# Altium schematic sheet MINIECO-ECO-CPU.SchDoc(MINIECO-ECO-CPU)
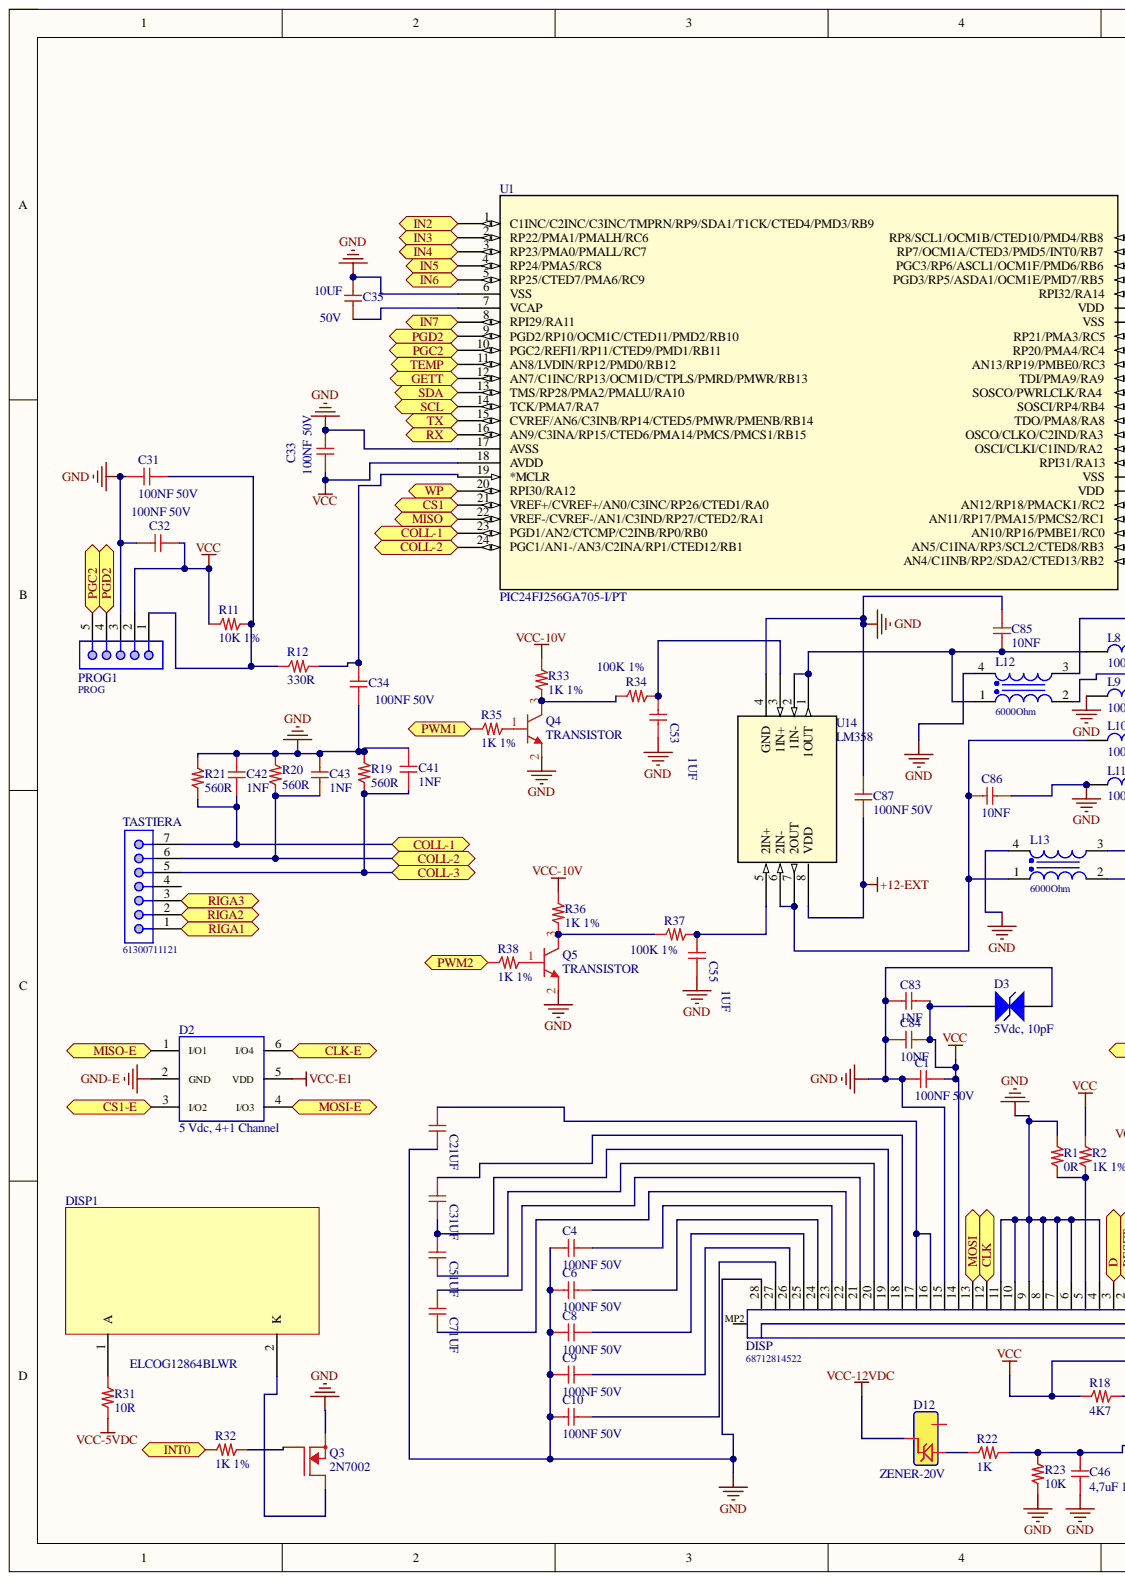
[diagram p0: MINIECO-ECO-CPU.SchDoc, left]
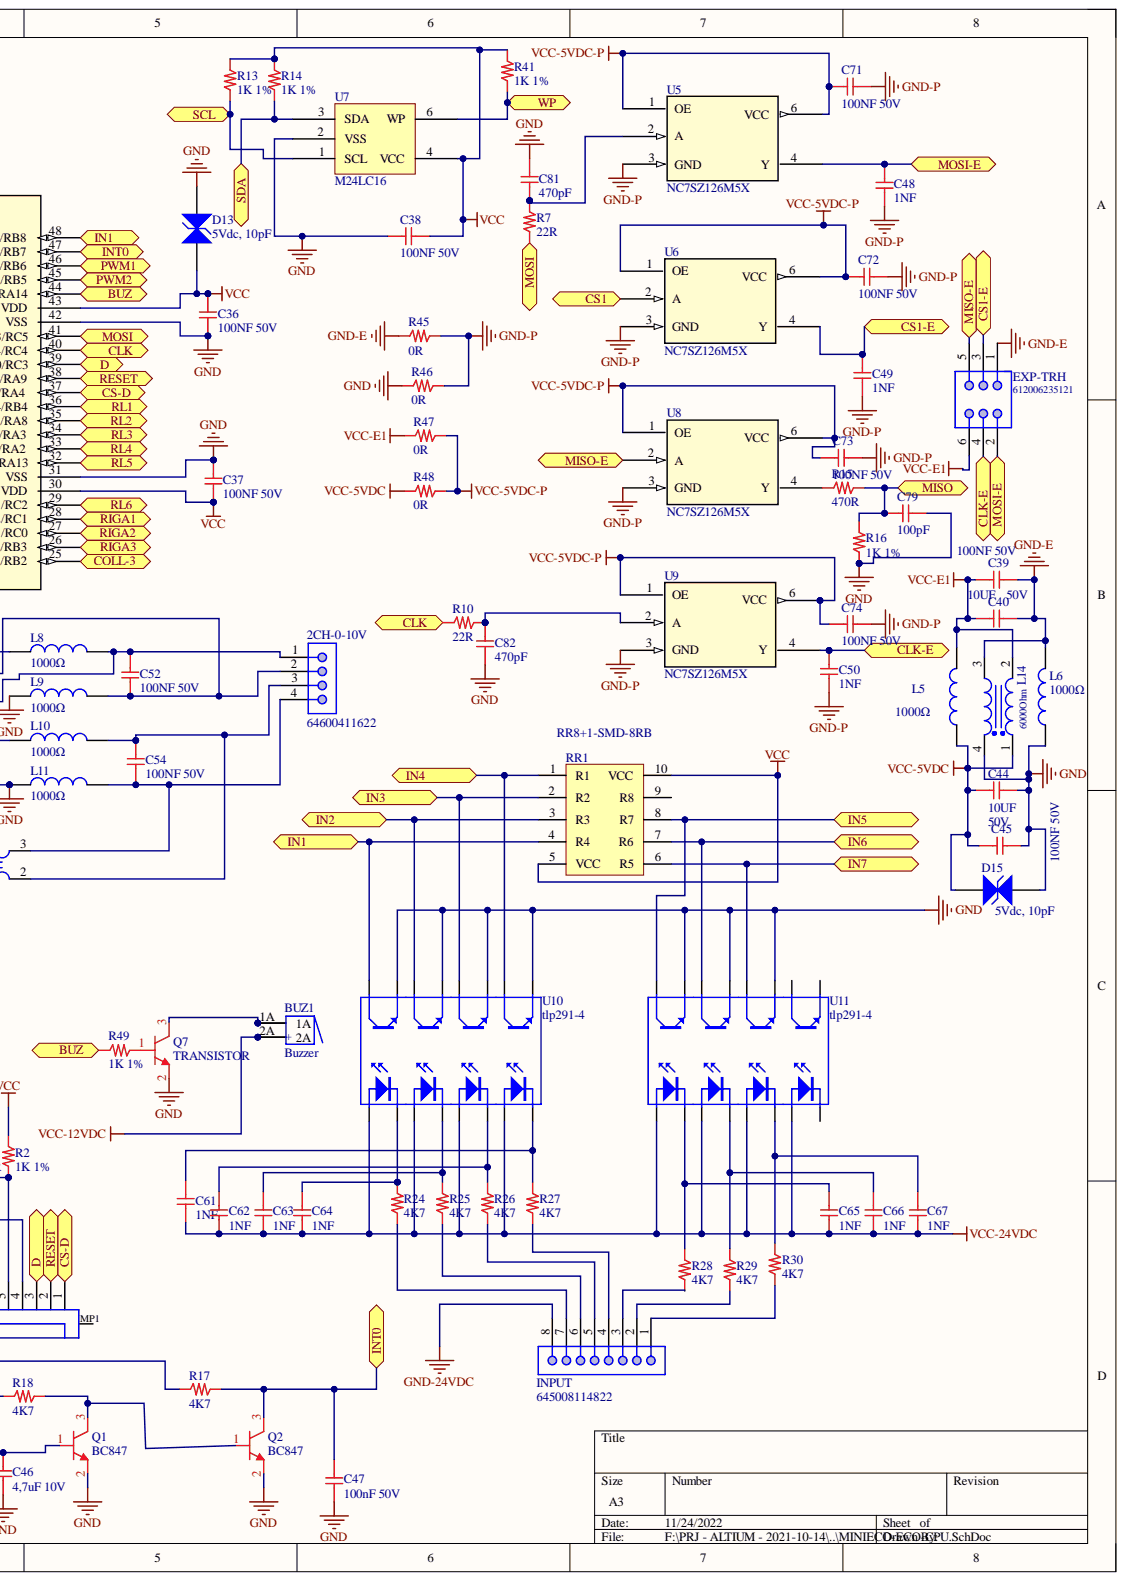
[diagram p1: MINIECO-ECO-CPU.SchDoc, right]

## Parts
2CH-0-10V  64600411622  [64600411622]
BUZ1  Buzzer  [BUZZER-14mm]
C1  100NF 50V  [0603_c]
C2  1UF  [0805_C]  (value not drawn)
C3  1UF  [0805_C]  (value not drawn)
C4  100NF 50V  [0603_c]
C5  1UF  [0805_C]  (value not drawn)
C6  100NF 50V  [0603_c]
C7  1UF  [0805_C]  (value not drawn)
C8  100NF 50V  [0603_c]
C9  100NF 50V  [0603_c]
C10  100NF 50V  [0603_c]
C31  100NF 50V  [0603_c]
C32  100NF 50V  [0603_c]
C33  100NF 50V  [0603_c]
C34  100NF 50V  [0603_c]
C35  10UF  Tensione: 50V  [1206_C]
C36  100NF 50V  [0603_c]
C37  100NF 50V  [0603_c]
C38  100NF 50V  [0603_c]
C39  100NF 50V  [0603_c]
C40  10UF  Tensione: 50V  [1206_C]
C41  1NF  [0603_c]
C42  1NF  [0603_c]
C43  1NF  [0603_c]
C44  10UF  Tensione: 50V  [1206_C]
C45  100NF 50V  [0603_c]
C46  4,7uF 10V  [0603_c]
C47  100nF 50V  [0603_c]
C48  1NF  [0603_c]
C49  1NF  [0603_c]
C50  1NF  [0603_c]
C52  100NF 50V  [0603_c]
C53  1UF  [0805_C]
C54  100NF 50V  [0603_c]
C55  1UF  [0805_C]
C61  1NF  [0402_C]
C62  1NF  [0402_C]
C63  1NF  [0402_C]
C64  1NF  [0402_C]
C65  1NF  [0402_C]
C66  1NF  [0402_C]
C67  1NF  [0402_C]
C71  100NF 50V  [0603_c]
C72  100NF 50V  [0603_c]
C73  100NF 50V  [0603_c]
C74  100NF 50V  [0603_c]
C79  100pF  [0603_c]
C81  470pF  [0603_c]
C82  470pF  [0603_c]
C83  1NF  [0603_c]
C84  10NF  [0603_c]
C85  10NF  [0603_c]
C86  10NF  [0603_c]
C87  100NF 50V  [0603_c]
D2  5 Vdc, 4+1 Channel  Channel: 4+1  [WE-TVS_High Speed_SOT23-6L]
D3  5Vdc, 10pF  Capacitance: 10pF  [WE-VE_0603]
D12  ZENER-20V  [SOT23]
D13  5Vdc, 10pF  Capacitance: 10pF  [WE-VE_0603]
D15  5Vdc, 10pF  Capacitance: 10pF  [WE-VE_0603]
DISP  68712814522  [68712814522]
DISP1  ELCOG12864BLWR  [ELCOG12864]
EXP-TRH  612006235121  [612006235121]
INPUT  645008114822  [645008114822]
L5  1000Ω  [WE-CBF_0805_Wide Band]
L6  1000Ω  [WE-CBF_0805_Wide Band]
L8  1000Ω  [WE-CBF_0805_Wide Band]
L9  1000Ω  [WE-CBF_0805_Wide Band]
L10  1000Ω  [WE-CBF_0805_Wide Band]
L11  1000Ω  [WE-CBF_0805_Wide Band]
L12  6000Ohm  [WE-CNSW_1206]
L13  6000Ohm  [WE-CNSW_1206]
L14  6000Ohm  [WE-CNSW_1206]
PROG1  PROG  [PROG1 - TR]
Q1  BC847  [SOT23]
Q2  BC847  [SOT23]
Q3  2N7002  [SOT23]
Q4  TRANSISTOR  [SOT23]
Q5  TRANSISTOR  [SOT23]
Q7  TRANSISTOR  [SOT23]
R1  0R  [0603_R]
R2  1K 1%  [0603_R]
R7  22R  [0603_R]
R10  22R  [0603_R]
R11  10K 1%  [0603_R]
R12  330R  [0603_R]
R13  1K 1%  [0603_R]
R14  1K 1%  [0603_R]
R15  470R  [0603_R]
R16  1K 1%  [0603_R]
R17  4K7  [0603_R]
R18  4K7  [0603_R]
R19  560R  [0603_R]
R20  560R  [0603_R]
R21  560R  [0603_R]
R22  1K  [0603_R]
R23  10K  [0603_R]
R24  4K7  [2512_R]
R25  4K7  [2512_R]
R26  4K7  [2512_R]
R27  4K7  [2512_R]
R28  4K7  [2512_R]
R29  4K7  [2512_R]
R30  4K7  [2512_R]
R31  10R  [0805_R]
R32  1K 1%  [0603_R]
R33  1K 1%  [0603_R]
R34  100K 1%  [0603_R]
R35  1K 1%  [0603_R]
R36  1K 1%  [0603_R]
R37  100K 1%  [0603_R]
R38  1K 1%  [0603_R]
R41  1K 1%  [0603_R]
R45  0R  [0603_R]
R46  0R  [0603_R]
R47  0R  [0603_R]
R48  0R  [0603_R]
R49  1K 1%  [0603_R]
RR1  RR8+1-SMD-8RB  [RR8+1-SMD]
TASTIERA  61300711121  [61300711121]
U1  PIC24FJ256GA705-I/PT  [QFP-48N]  (value not drawn)
U5  NC7SZ126M5X  [SOT23-5_N]
U6  NC7SZ126M5X  [SOT23-5_N]
U7  M24LC16  [SOT23-5_N]
U8  NC7SZ126M5X  [SOT23-5_N]
U9  NC7SZ126M5X  [SOT23-5_N]
U10  tlp291-4  [SO16_NO]
U11  tlp291-4  [SO16_NO]
U14  LM358  [SO-8]

## Ports
BUZ, CLK, CLK-E, COLL-1, COLL-2, COLL-3, CS1, CS1-E, CS-D, D, GETT, IN1, IN2, IN3, IN4, IN5, IN6, IN7, INT0, MISO, MISO-E, MOSI, MOSI-E, PGC2, PGD2, PWM1, PWM2, RESET, RIGA1, RIGA2, RIGA3, RL1, RL2, RL3, RL4, RL5, RL6, RX, SCL, SDA, TEMP, TX, WP

## Nets
+12-EXT: C87.1 U14.8(VDD)
GND: C1.1 C2.2 C4.1 C6.1 C8.1 C9.1 C10.1 C31.1 C32.1 C33.1 C34.2 C35.1 C36.2 C37.1 C38.1 C41.1 C42.1 C43.1 C44.2 C45.2 C46.2 C47.2 C53.2 C55.2 C79.2 C81.1 C82.2 C83.1 C84.1 C85.1 C86.2 C87.2 D3.1 D13.2 D15.1 DISP.4 DISP.6 DISP.7 DISP.8 DISP.9 DISP.10 DISP.11 DISP.15 DISP.28 L6.1 L9.1 L11.1 L12.4 L13.4 L14.4 PROG1.3 Q1.2 Q2.2 Q3.2 Q4.2 Q5.2 Q7.2 R1.1 R16.2 R19.1 R20.1 R21.1 R23.2 R46.1 U1.6(VSS) U1.17(AVSS) U1.31(VSS) U1.42(VSS) U7.2(VSS) U10.9 U10.11 U10.13 U10.15 U11.11 U11.13 U11.15 U14.4(GND)
GND-24VDC: INPUT.8
GND-E: C39.2 C40.2 D2.2(GND) EXP-TRH.1 L6.2 L14.3 R45.1
GND-P: C48.2 C49.2 C50.2 C71.2 C72.2 C73.1 C74.2 R45.2 R46.2 U5.3(GND) U6.3(GND) U8.3(GND) U9.3(GND)
VCC: C1.2 C32.2 C33.2 C36.1 C37.2 C38.2 C83.2 C84.2 D3.2 D13.1 DISP.14 PROG1.2 R2.1 R11.1 R13.1 R14.1 R17.2 R18.2 R41.1 RR1.5(VCC) RR1.10(VCC) U1.18(AVDD) U1.30(VDD) U1.43(VDD) U7.4(VCC)
VCC-5VDC: C44.1 C45.1 D15.2 L5.1 L14.1 R31.2 R48.1
VCC-5VDC-P: C71.1 C72.1 C73.2 C74.1 R47.2 R48.2 U5.1(OE) U5.6(VCC) U6.1(OE) U6.6(VCC) U8.1(OE) U8.6(VCC) U9.1(OE) U9.6(VCC)
VCC-10V: R33.1 R36.1
VCC-12VDC: BUZ1.2 BUZ1.2A D12.3
VCC-24VDC: C61.2 C62.2 C63.2 C64.2 C65.2 C66.2 C67.2 U10.1 U10.3 U10.5 U10.7 U11.1 U11.3 U11.5 U11.7
VCC-E1: C39.1 C40.1 D2.5(VDD) EXP-TRH.6 L5.2 L14.2 R47.1
BUZ: R49.1 U1.44(RPI32/RA14) ; port BUZ
CLK: DISP.12 R10.1 U1.40(RP20/PMA4/RC4) ; port CLK
CLK-E: C50.1 D2.6(I/O4) EXP-TRH.4 U9.4(Y) ; port CLK-E
COLL-1: C42.2 R21.2 TASTIERA.7 U1.23(PGD1/AN2/CTCMP/C2INB/RP0/RB0) ; port COLL-1
COLL-2: C43.2 R20.2 TASTIERA.6 U1.24(PGC1/AN1-/AN3/C2INA/RP1/CTED12/RB1) ; port COLL-2
COLL-3: C41.2 R19.2 TASTIERA.5 U1.25(AN4/C1INB/RP2/SDA2/CTED13/RB2) ; port COLL-3
CS1: U1.21(VREF+/CVREF+/AN0/C3INC/RP26/CTED1/RA0) U6.2(A) ; port CS1
CS1-E: C49.1 D2.3(I/O2) EXP-TRH.3 U6.4(Y) ; port CS1-E
CS-D: DISP.1 U1.37(SOSCO/PWRLCLK/RA4) ; port CS-D
D: DISP.3 U1.39(AN13/RP19/PMBE0/RC3) ; port D
GETT: U1.12(AN7/C1INC/RP13/OCM1D/CTPLS/PMRD/PMWR/RB13) ; port GETT
IN1: RR1.4(R4) U1.48(RP8/SCL1/OCM1B/CTED10/PMD4/RB8) U10.16 ; port IN1
IN2: RR1.3(R3) U1.1(C1INC/C2INC/C3INC/TMPRN/RP9/SDA1/T1CK/CTED4/PMD3/RB9) U10.14 ; port IN2
IN3: RR1.2(R2) U1.2(RP22/PMA1/PMALH/RC6) U10.12 ; port IN3
IN4: RR1.1(R1) U1.3(RP23/PMA0/PMALL/RC7) U10.10 ; port IN4
IN5: RR1.8(R7) U1.4(RP24/PMA5/RC8) U11.16 ; port IN5
IN6: RR1.7(R6) U1.5(RP25/CTED7/PMA6/RC9) U11.14 ; port IN6
IN7: RR1.6(R5) U1.8(RPI29/RA11) U11.12 ; port IN7
INT0: C47.1 Q2.3 R17.1 R32.1 U1.47(RP7/OCM1A/CTED3/PMD5/INT0/RB7) ; port INT0
MISO: C79.1 R15.2 R16.1 U1.22(VREF-/CVREF-/AN1/C3IND/RP27/CTED2/RA1) ; port MISO
MISO-E: D2.1(I/O1) EXP-TRH.5 U8.2(A) ; port MISO-E
MOSI: DISP.13 R7.1 U1.41(RP21/PMA3/RC5) ; port MOSI
MOSI-E: C48.1 D2.4(I/O3) EXP-TRH.2 U5.4(Y) ; port MOSI-E
PGC2: PROG1.5 U1.10(PGC2/REFI1/RP11/CTED9/PMD1/RB11) ; port PGC2
PGD2: PROG1.4 U1.9(PGD2/RP10/OCM1C/CTED11/PMD2/RB10) ; port PGD2
PWM1: R35.1 U1.46(PGC3/RP6/ASCL1/OCM1F/PMD6/RB6) ; port PWM1
PWM2: R38.1 U1.45(PGD3/RP5/ASDA1/OCM1E/PMD7/RB5) ; port PWM2
RESET: DISP.2 U1.38(TDI/PMA9/RA9) ; port RESET
RIGA1: TASTIERA.1 U1.28(AN11/RP17/PMA15/PMCS2/RC1) ; port RIGA1
RIGA2: TASTIERA.2 U1.27(AN10/RP16/PMBE1/RC0) ; port RIGA2
RIGA3: TASTIERA.3 U1.26(AN5/C1INA/RP3/SCL2/CTED8/RB3) ; port RIGA3
RL1: U1.36(SOSCI/RP4/RB4) ; port RL1
RL2: U1.35(TDO/PMA8/RA8) ; port RL2
RL3: U1.34(OSCO/CLKO/C2IND/RA3) ; port RL3
RL4: U1.33(OSCI/CLKI/C1IND/RA2) ; port RL4
RL5: U1.32(RPI31/RA13) ; port RL5
RL6: U1.29(AN12/RP18/PMACK1/RC2) ; port RL6
RX: U1.16(AN9/C3INA/RP15/CTED6/PMA14/PMCS/PMCS1/RB15) ; port RX
SCL: R13.2 U1.14(TCK/PMA7/RA7) U7.1(SCL) ; port SCL
SDA: R14.2 U1.13(TMS/RP28/PMA2/PMALU/RA10) U7.3(SDA) ; port SDA
TEMP: U1.11(AN8/LVDIN/RP12/PMD0/RB12) ; port TEMP
TX: U1.15(CVREF/AN6/C3INB/RP14/CTED5/PMWR/PMENB/RB14) ; port TX
WP: R41.2 U1.20(RPI30/RA12) U7.6(WP) ; port WP
Net2CH-0-10V_1: 2CH-0-10V.1 C52.1 L8.2 L12.2
Net2CH-0-10V_2: 2CH-0-10V.2 C52.2 L9.2 L12.3
Net2CH-0-10V_3: 2CH-0-10V.3 C54.1 L10.2 L13.2
Net2CH-0-10V_4: 2CH-0-10V.4 C54.2 L11.2 L13.3
NetBUZ1_1: BUZ1.1 BUZ1.1A Q7.3
NetC2_1: C2.1 DISP.16 DISP.17
NetC3_1: C3.1 DISP.18
NetC3_2: C3.2 C5.1 DISP.19
NetC4_2: C4.2 DISP.23
NetC5_2: C5.2 DISP.20
NetC6_2: C6.2 DISP.24
NetC7_1: C7.1 DISP.21
NetC7_2: C7.2 DISP.22
NetC8_2: C8.2 DISP.25
NetC9_2: C9.2 DISP.26
NetC10_2: C10.2 DISP.27
NetC31_2: C31.2 PROG1.1 R11.2 R12.2
NetC34_1: C34.1 R12.1 U1.19(*MCLR)
NetC35_2: C35.2 U1.7(VCAP)
NetC46_1: C46.1 Q1.1 R22.1 R23.1
NetC53_1: C53.1 R34.2 U14.3(1IN+)
NetC55_1: C55.1 R37.2 U14.5(2IN+)
NetC61_1: C61.1 R27.1 U10.8
NetC62_1: C62.1 R26.1 U10.6
NetC63_1: C63.1 R25.1 U10.4
NetC64_1: C64.1 R24.1 U10.2
NetC65_1: C65.1 R28.1 U11.2
NetC66_1: C66.1 R29.1 U11.4
NetC67_1: C67.1 R30.1 U11.6
NetC81_2: C81.2 R7.2 U5.2(A)
NetC82_1: C82.1 R10.2 U9.2(A)
NetC85_2: C85.2 L8.1 L12.1 U14.1(1OUT) U14.2(1IN-)
NetC86_1: C86.1 L10.1 L13.1 U14.6(2IN-) U14.7(2OUT)
NetD12_1: D12.1 R22.2
NetDISP1_1: DISP1.1 R31.1
NetDISP1_2: DISP1.2 Q3.3
NetDISP_5: DISP.5 R1.2 R2.2
NetINPUT_1: INPUT.1 R30.2
NetINPUT_2: INPUT.2 R29.2
NetINPUT_3: INPUT.3 R28.2
NetINPUT_4: INPUT.4 R27.2
NetINPUT_5: INPUT.5 R26.2
NetINPUT_6: INPUT.6 R25.2
NetINPUT_7: INPUT.7 R24.2
NetQ1_3: Q1.3 Q2.1 R18.1
NetQ3_1: Q3.1 R32.2
NetQ4_1: Q4.1 R35.2
NetQ4_3: Q4.3 R33.2 R34.1
NetQ5_1: Q5.1 R38.2
NetQ5_3: Q5.3 R36.2 R37.1
NetQ7_1: Q7.1 R49.2
NetR15_1: R15.1 U8.4(Y)

Not connected: D12 2; DISP MP1 MP2; RR1 9(R8); TASTIERA 4; U11 8 9 10

## Library data of the parts
2CH-0-10V = 64600411622: WR-WTB Male Vertical Shrouded Header, pitch 2.5mm, 4p; Contact Resistance: 20mOhm; Pin Number: 4; Rated Current: 3A; Withstanding Voltage: 1000VAC; Working Voltage: 250VAC; Component Kind: Standard; ComponentLink1Description: Manufacturer; ComponentLink1URL: http://www.we-online.de; ComponentLink2Description: Datasheet; ComponentLink2URL: https://www.we-online.com/catalog/datasheet/64600411622.pdf; Gender: Male; Library Name: WR-WTB_2.50mm.SchLib; Mounting Technology: THT; Operating Temperature: -40 to +105degC; Packaging: Bag; Part Number: 64600411622; Pitch: 2.5mm; Published Date: January 2020; Type: Vertical; Publisher: Wurth Elektronik
BUZ1 = Buzzer: Magnetic Transducer Buzzer; Component Kind: Standard; ComponentLink1Description: Manufacturer Link; ComponentLink1URL: http://www.megastar.com/linecard/buzzmode/index.php; DatasheetVersion: 17-Dec-2003; LatestRevisionDate: 17-Dec-2003; LatestRevisionNote: Schematic revised, PCB Footprint replaced.; PackageDescription: Magnetic Transducer Buzzer; PackageReference: ABSM-1574; Published: 24-Mar-1999; Library Name: HSW.SchLib; Publisher: Altium Limited
C1 C4 C6 C8-C10 C31-C34 C36-C39 C45-C47 C52 C54 C71-C74 C87 = 100NF 50V: Cap X7R 100nF 50V Flex Terminal; Cod. Interno: COCS50665050104; Cod. Produttore: CL10B104KB8SFNC; Produttore: Samsung; Cod. DigiKey: 1276-1936-2-ND; Component Kind: Standard; Library Name: CapSMD0603.SchLib
C2 C3 C5 C7 C53 C55 = 1UF 100V: Cap X7S 1UF 100V; Cod. Interno: COCS 567061010105; Cod. Produttore: C2012X7S2A105K125AE; Produttore: TDK; Cod. DigiKey: 445-8887-2-ND; Component Kind: Standard; Library Name: CapSMD0805.SchLib; Temperature Range: Da -55°C a +125°C
C35 C40 C44 = 10UF 50V: Cap X5R 10UF 50V; Cod. Produttore: GRM31CR61H106KA12L; Produttore: MURATA; Cod. Farnell: 2666583; Component Kind: Standard; Library Name: CapSMD1206.SchLib; Temperature Range: Da -55°C a +125°C
C41-C43 C48-C50 C83 = 1NF 50V: Cap X7R 1nF 50V; Cod. Interno: COCS 50665050102; Component Kind: Standard; Library Name: CapSMD0603.SchLib
C61-C67 = 1NF 50V: Cap X7R 1NF 50V; Cod. Interno: COCS556565000102; Cod. Produttore: ??; Component Kind: Standard; Library Name: CapSMD0402.SchLib; Produttore: ??
C79 = 100pF 50V: Cap X7R 100pF 50V; Cod. Interno: COCS??; Cod. Produttore: ??; Component Kind: Standard; Library Name: CapSMD0603.SchLib; Produttore: ??
C81 C82 = 470pF 50V: Cap X7R 470pF 50V; Cod. Interno: COCS??; Cod. Produttore: ??; Component Kind: Standard; Library Name: CapSMD0603.SchLib; Produttore: ??
C84-C86 = 10NF 50V: Cap X7R 10nF 50V; Cod. Interno: COCS 556655000103; Cod. Produttore: CL05B103KB5NNNC; Produttore: Samsung; Cod. DigiKey: 1276-1028-2-ND; Component Kind: Standard; Library Name: CapSMD0603.SchLib
D2 = 824015: TVS Diode - High Speed Series WE-TVS, SOT23-6L, 5 Vdc, 4+1 Channel; Channel Input Capacitance: 2 pF; DC Operating Voltage: 5 V; Reverse Breakdown Voltage min.: 6.1 V; Reverse Channel Leakage Current: 2 uA; Reverse Peak Pulse Current: 12 A; Component Kind: Standard; ComponentLink1Description: Manufacturer; ComponentLink1URL: http://www.we-online.de; ComponentLink2Description: Datasheet; ComponentLink2URL: https://katalog.we-online.de/pbs/datasheet/824015.pdf; Library Name: WE-TVS_High Speed Series.SchLib; Mounting Technology: SMT; Operating Temperature: -40 to 125 degC; Packaging: Tape and Reel; Part Number: 824015; Published Date: November 2018; Size: SOT23-6L; Publisher: Wurth Elektronik
D3 D13 D15 = 82356050100: WE-VE ESD Suppressor, size 0603, 5Vdc, 10pF; Clamp Voltage: 60V; ESD Voltage air discharge: 15kV; Leakage Current: 1uA; Component Kind: Standard; ComponentLink1Description: Manufacturer; ComponentLink1URL: http://www.we-online.de; ComponentLink2Description: Datasheet; ComponentLink2URL: https://katalog.we-online.com/pbs/datasheet/82356050100.pdf; Library Name: WE-VE_0603.SchLib; Mounting Technology: SMT; Operating Temperature: -40 to +85degC; Packaging: Tape and Reel; Part Number: 82356050100; Published Date: June 2019; Size: 0603; Working Voltage: 5Vdc; Publisher: Wurth Elektronik
D12 = CMPZ5241B: DIODE ZENER 11V 300MW SOT23; Cod. Interno: ZENS 620CMPZ5241B; Produttore: Central Semiconductor; Vz: 10.45V - 11.55V; Cod. Produttore: CMPZ5241B; Component Kind: Standard; Library Name: DiodiZENER.SchLib
DISP = 68712814522: SMT ZIF Connectors WR-FPC, Horizontal, Bottom Contact, pitch 0.5 mm, 28 pins; Contact Resistance: 20 mOhm; Pin Number: 28; Pitch: 0.5 mm; Rated Current: 0.5 A; Withstanding Voltage: 250 VAC; Working Voltage: 50 VAC; Component Kind: Standard; ComponentLink1Description: Manufacturer; ComponentLink1URL: http://www.we-online.de; ComponentLink2Description: Datasheet; ComponentLink2URL: https://katalog.we-online.de/em/datasheet/68712814522.pdf; Contact Design: Bottom Contact; Library Name: ZIF Connectors WR-FPC_0.50 mm.SchLib; Mounting Technology: SMT; Operating Temperature: -25 to 85 degC; Orientation: Horizontal; Packaging: Tape and Reel; Part Number: 68712814522; Published Date: August 2018; Publisher: Wurth Elektronik
EXP-TRH = 612006235121: Male Box Header WR-BHD, THT, Vertical, pitch 2.54 mm, 6 pins; Contact Resistance: 20 mOhm; Pin Number: 6; Pitch: 2.54 mm; Withstanding Voltage: 500 VAC; Working Voltage: 250 VAC; Component Kind: Standard; ComponentLink1Description: Manufacturer; ComponentLink1URL: http://www.we-online.de; ComponentLink2Description: Datasheet; ComponentLink2URL: https://katalog.we-online.de/em/datasheet/6120xx235121.pdf; Gender: Male; Library Name: WR-BHD_Box Headers_2.54mm.SchLib; Mounting Technology: THT; Operating Temperature: -40 to 125 degC; Orientation: Vertical; Packaging: Tray; Part Number: 612006235121; Published Date: August 2018; Publisher: Wurth Elektronik
INPUT = 645008114822: WR-WTB THT Male Vertical Locking Header, pitch 3.96mm, 8p; Contact Resistance: 20mOhm; Pin Number: 8; Rated Current: 7A; Withstanding Voltage: 1500VAC; Working Voltage: 250VAC; Component Kind: Standard; ComponentLink1Description: Manufacturer; ComponentLink1URL: http://www.we-online.de; ComponentLink2Description: Datasheet; ComponentLink2URL: https://www.we-online.com/catalog/datasheet/645008114822.pdf; Gender: Male; Library Name: WR-WTB_3.96mm.SchLib; Mounting Technology: THT; Operating Temperature: -40 to +105degC; Packaging: Bag; Part Number: 645008114822; Pitch: 3.96mm; Published Date: January 2020; Type: Vertical; Publisher: Wurth Elektronik
L5 L6 L8-L11 = WE-CBF, 74279205: WE-CBF SMD EMI Suppression Ferrite Bead, 0805, 1000Ω; Type: Wide Band; Component Kind: Standard; ComponentLink1Description: Manufacturer; ComponentLink1URL: http://www.we-online.de; ComponentLink2Description: Datasheet; ComponentLink2URL: http://katalog.we-online.de/pbs/datasheet/74279205.pdf; Library Name: WE-CBF_0805.SchLib; PartNumber: 74279205; PublishedDate: Aug 2016; Size: 0805; Value: 1000Ω; Publisher: Wurth Elektronik
L12-L14 = 744232101: WE-CNSW SMT Common Mode Line Filter, size 1206, 6000Ohm, 0.09A, 50V; Manufacturer: Wurth Elektronik; DC Resistance max.: 5.5Ohm; Impedance Test Condition: 100MHz; Insulation Test Voltage: 125VAC; Winding Style: bifiliar; Component Kind: Standard; ComponentLink1Description: Manufacturer; ComponentLink1URL: http://www.we-online.de; ComponentLink2Description: Datasheet; ComponentLink2URL: https://www.we-online.com/catalog/datasheet/744232101.pdf; Impedance: 6000Ohm; Library Name: WE-CNSW_1206.SchLib; Manufacturer Part Number: 744232101; Mounting Technology: SMT; Operating Temperature: -40 to +125degC; Packaging: Tape and Reel; Published Date: April 2020; Rated Current: 0.09A; Rated Voltage: 50V; Size: 1206
PROG1 = PROG1-TR: SMT Vertical Pin Header WR-PHD, Pitch 2.54 mm, Single Row, 6 pins; Part Number: 61000618321; Contact Resistance: 20 mOhm; Gender: Male; Pin Number: 6; Pitch: 2.54 mm; Rated Current: 3 A; Withstanding Voltage: 500 VAC; Working Voltage: 250 VAC; Component Kind: Standard; ComponentLink1Description: Manufacturer; ComponentLink1URL: http://www.we-online.de; ComponentLink2Description: Datasheet; ComponentLink2URL: https://katalog.we-online.de/em/datasheet/6100xx18321.pdf; Mounting Technology: SMT; Operating Temperature: -40 to 125 degC; Orientation: Vertical; Packaging: Tube; Published Date: August 2018; Row Number: Single; Library Name: HSW.SchLib; Publisher: Wurth Elektronik
Q1 Q2 = MMBT3904LT1G: NPN Si Digital Transistor; Cod. Interno: TRXS 110MMBT3904LT1G; Ft: 300MHz; Ic: 200mA; Produttore: ON Semiconductor; Ptot: 300mW; Vcb: 60V; Vce: 40V; Cod. Produttore: MMBT3904LT1G; Component Kind: Standard; Library Name: TransitorSMD.SCHLIB
Q3 = 2N7002: N-Channel 60V 0.3A MosFET; Cod. Interno: TRXS1100002N7002; Produttore: NXP; Cod. Produttore: 2N7002; Component Kind: Standard; Library Name: MosfetSMD.SchLib
Q4 Q5 Q7 = BC847B: NPN Silicon Darlington Transistors; Cod. Interno: TRXS ??; Cod. Produttore: BCV27; Ic: 500mA; Produttore: INFINEON; Vcb: 40V; Vce: 30V; Component Kind: Standard; Library Name: HSW.SchLib
R1 R45-R48 = 0R: Res Film Spesso 1/16W 0R 5%; Cod. Interno: RESS 4166556B0000; Component Kind: Standard; Library Name: ResSMD0603.SchLib
R2 R13 R14 R16 R32 R33 R35 R36 R38 R41 R49 = 1K 1%: Res Film Spesso 1/16W 1K 1%; Cod. Interno: RESS4166356B0102; Cod. Produttore: ??; Component Kind: Standard; Library Name: ResSMD0603.SchLib; Produttore: ??
R7 R10 = 22R: Res Film Spesso 1/16W 22R 5%; Cod. Produttore: ??; Component Kind: Standard; Library Name: ResSMD0603.SchLib; Produttore: ??
R11 = 10K 1%: Res Film Spesso 1/16W 10K 1%; Cod. Interno: RESS4166356B0103; Cod. Produttore: ERJ-3EKF1002V; Produttore: Panasonic; Component Kind: Standard; Library Name: ResSMD0603.SchLib
R12 = 330R: Res Film Spesso 1/16W 330R 5%; Cod. Interno: RESS4166556B0331; Component Kind: Standard; Library Name: ResSMD0603.SchLib
R15 = 470R: Res Film Spesso 1/16W 470R 5%; Cod. Interno: RESS4166556B0471??; Cod. Produttore: ??; Component Kind: Standard; Library Name: ResSMD0603.SchLib; Produttore: ??
R17 R18 R22 R23 = 2K7: Res Film Spesso 1/16W 2K7 5%; Cod. Interno: RESS4166556B0272; Cod. Produttore: CRCW06032K70JNEA; Produttore: VISHAY; Component Kind: Standard; Library Name: ResSMD0603.SchLib
R19-R21 = 560R: Res Film Spesso 1/16W 560R 5%; Cod. Interno: RESS 4166556B0561; Component Kind: Standard; Library Name: ResSMD0603.SchLib
R24-R30 = 4K7: Precision Thick Film 4K7 1W 1%; Cod. Interno: RESS 4190310A54R9; Cod. Produttore: ERJ-1TNF54R9U; Produttore: Vishay Dale; Cod. DigiKey: PT54.9AFTR-ND; Component Kind: Standard; Library Name: ResSMD2512.SchLib
R31 = 10R: Res Film Spesso 1/8W 10R 5%; Cod. Interno: RESS4170555B0100; Potenza: 1/8W 5%; Component Kind: Standard; Library Name: ResSMD0805.SchLib
R34 R37 = 100K 1%: Res Film Spesso 1/16W 100K 1%; Cod. Interno: RESS4166360C0104; Cod. Produttore: ??; Component Kind: Standard; Library Name: ResSMD0603.SchLib; Produttore: ??
TASTIERA = 61300711121: THT Vertical Pin Header WR-PHD, Pitch 2.54 mm, Single Row, 7 pins; Contact Resistance: 20 mOhm; Gender: Male; Pin Number: 7; Pitch: 2.54 mm; Rated Current: 3 A; Withstanding Voltage: 500 VAC; Working Voltage: 250 VAC; Component Kind: Standard; ComponentLink1Description: Manufacturer; ComponentLink1URL: http://www.we-online.de; ComponentLink2Description: Datasheet; ComponentLink2URL: https://katalog.we-online.de/em/datasheet/6130xx11121.pdf; Library Name: Pin Header WR-PHD_2.54 mm.SchLib; Mounting Technology: THT; Operating Temperature: -40 to 105 degC; Orientation: Vertical; Packaging: Bag; Part Number: 61300711121; Published Date: August 2018; Row Number: Single; Publisher: Wurth Elektronik
U1 = PIC24FJ256GA705-I/PT: 16-Bit General Purpose Microcontrollers with 256-Kbyte Flash and 16-Kbyte RAM in Low Pin Count Packages; Manufacturer_Part_Number: PIC24FJ256GA705-I/M4; Built_By: EMA_Cory; SourceLibrary: Add_to_database_2020-11-20; Vendor: Microchip; Component Kind: Standard; Datasheet: https://ww1.microchip.com/downloads/en/DeviceDoc/PIC24FJ256GA705-Family-Data-Sheet-DS30010118E.pdf; RefDes: RefDes; Type: DEV; Copyright: Copyright (C) 2018 Accelerated Designs. All rights reserved; Library Name: HSW.SchLib
U5 U6 U8 U9 = NC7SZ126M5X: No Description Available; Manufacturer_Part_Number: SN74LVC1G125DBVR; Built_By: EMA_UL_Team; Vendor: Texas Instruments; Component Kind: Standard; RefDes: RefDes; Type: DEV; Value: Value; Copyright: Copyright (C) 2018 Accelerated Designs. All rights reserved; Library Name: HSW.SchLib
U10 U11 = tlp291_4: 4-Pin Phototransistor Optocoupler; Component Kind: Standard; DatasheetVersion: Nov-2003; LatestRevisionDate: 04-Mar-2005; LatestRevisionNote: Sch pins 3 & 4 Swapped.; PackageReference: DIP-4; Published: 24-Mar-1999; Library Name: HSW.SchLib; Publisher: Altium Limited
U14 = LM358: Industry-Standard Dual Operational Amplifiers; Manufacturer_Part_Number: TLC272CDR; Built_By: EMA_UL_Team; Vendor: Texas Instruments; Component Kind: Standard; RefDes: RefDes; Type: DEV; Value: Value; Copyright: Copyright (C) 2018 Accelerated Designs. All rights reserved; Library Name: HSW.SchLib
DISP1 = ELCOG12864BLWR: Component Kind: Standard; Library Name: POLMAC-WIFI.SCHLIB
RR1 = RR8+1-SMD-8RB: Component Kind: Standard; Library Name: HSW.SchLib
U7 = M24LC16: Component Kind: Standard; Library Name: HSW.SchLib
